# Altium schematic sheet FPGA.SchDoc(FPGA)
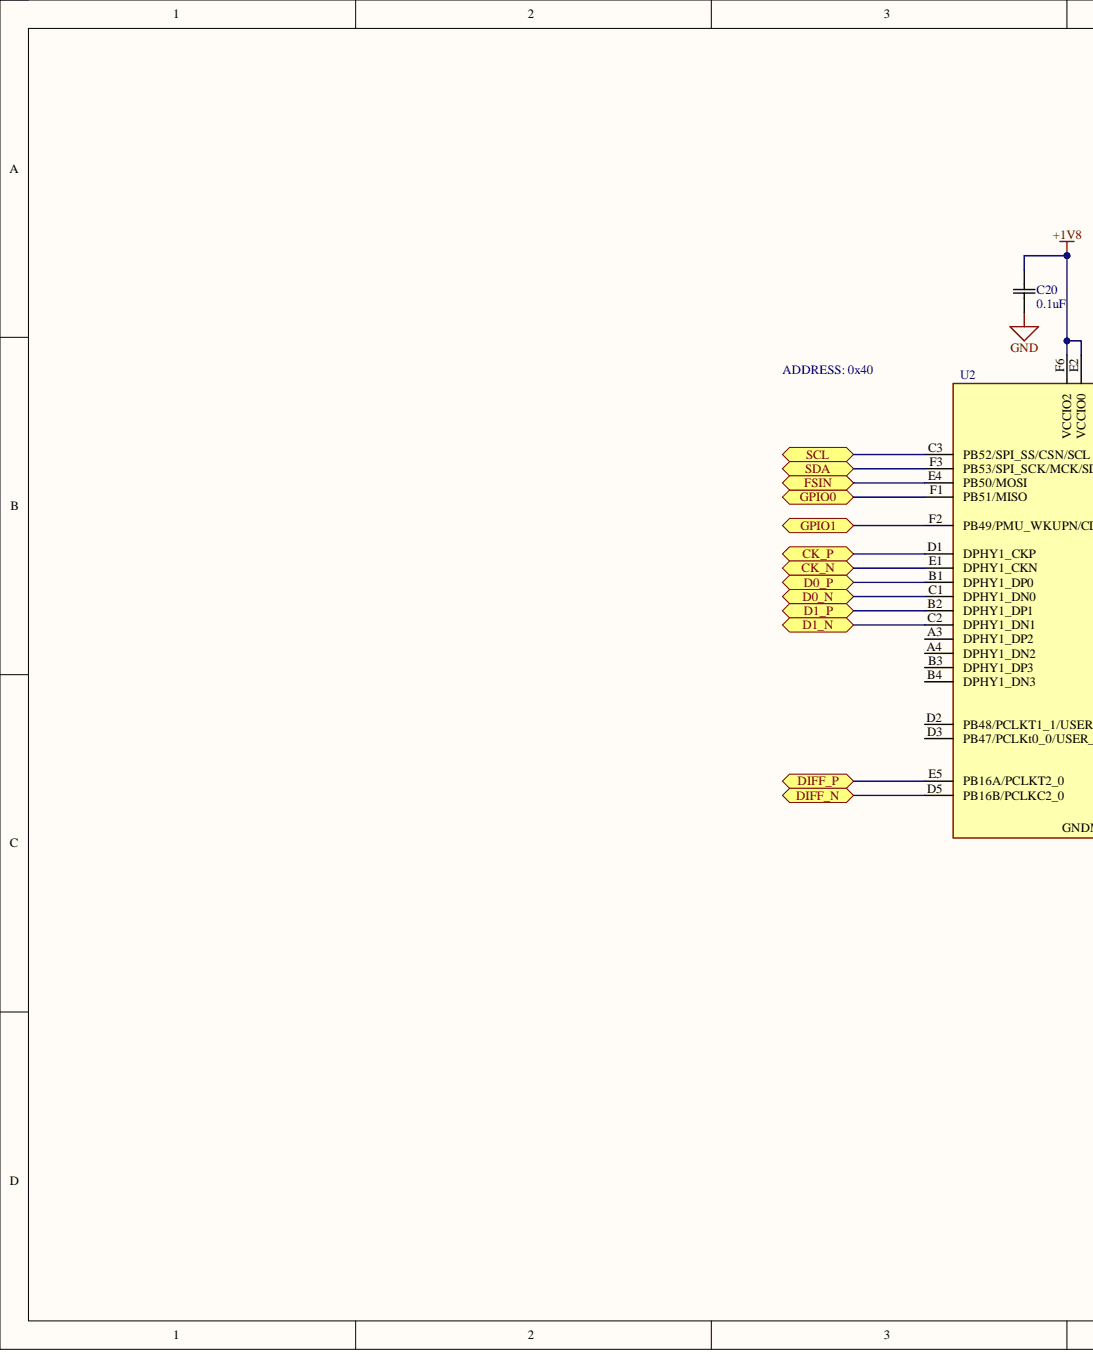
[diagram p0: FPGA.SchDoc, left]
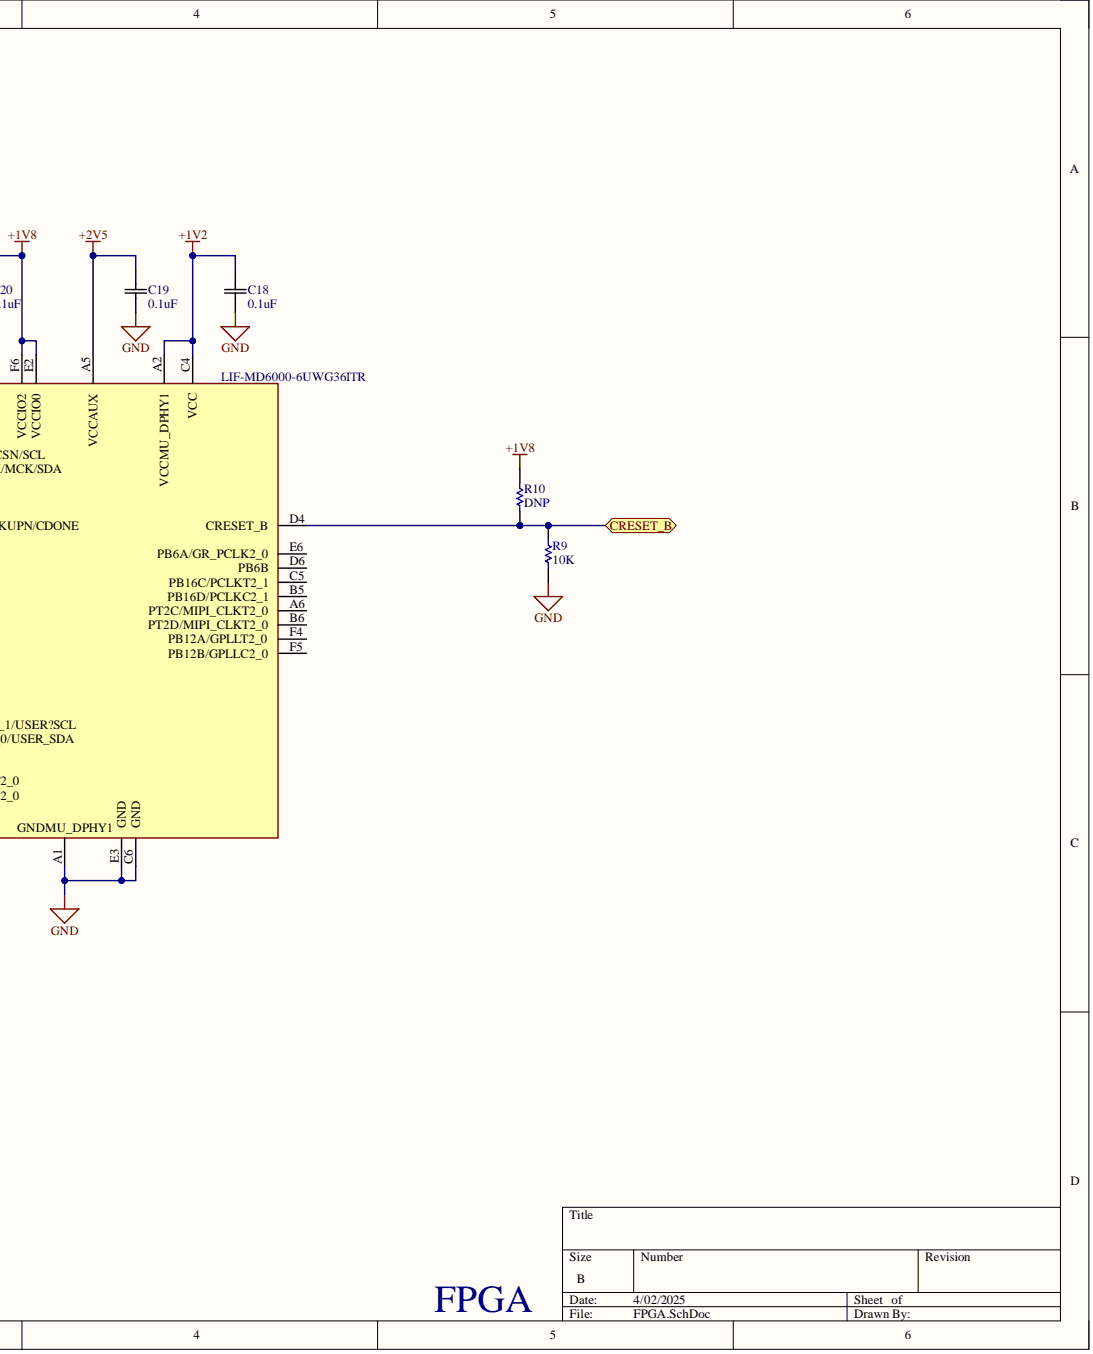
[diagram p1: FPGA.SchDoc, right]

## Parts
C18  0.1uF
C19  0.1uF
C20  0.1uF
R9  10K
R10  DNP
U2  LIF-MD6000-6UWG36ITR

## Ports
CK_N, CK_P, CRESET_B, D0_N, D0_P, D1_N, D1_P, DIFF_N, DIFF_P, FSIN, GPIO0, GPIO1, SCL, SDA

## Nets
+1V2: C18.2 U2.A2(VCCMU_DPHY1) U2.C4(VCC)
+1V8: C20.2 R10.2 U2.E2(VCCIO0) U2.F6(VCCIO2)
+2V5: C19.2 U2.A5(VCCAUX)
GND: C18.1 C19.1 C20.1 R9.1 U2.A1 U2.C6(GND) U2.E3(GND)
CK_N: U2.E1(DPHY1_CKN) ; port CK_N
CK_P: U2.D1(DPHY1_CKP) ; port CK_P
CRESET_B: R9.2 R10.1 U2.D4(CRESET_B) ; port CRESET_B
D0_N: U2.C1(DPHY1_DN0) ; port D0_N
D0_P: U2.B1(DPHY1_DP0) ; port D0_P
D1_N: U2.C2(DPHY1_DN1) ; port D1_N
D1_P: U2.B2(DPHY1_DP1) ; port D1_P
DIFF_N: U2.D5(PB16B/PCLKC2_0) ; port DIFF_N
DIFF_P: U2.E5(PB16A/PCLKT2_0) ; port DIFF_P
FSIN: U2.E4(PB50/MOSI) ; port FSIN
GPIO0: U2.F1(PB51/MISO) ; port GPIO0
GPIO1: U2.F2(PB49/PMU_WKUPN/CDONE) ; port GPIO1
SCL: U2.C3(PB52/SPI_SS/CSN/SCL) ; port SCL
SDA: U2.F3(PB53/SPI_SCK/MCK/SDA) ; port SDA

Not connected: U2 A3(DPHY1_DP2) A4(DPHY1_DN2) A6(PT2C/MIPI_CLKT2_0) B3(DPHY1_DP3) B4(DPHY1_DN3) B5(PB16D/PCLKC2_1) B6(PT2D/MIPI_CLKT2_0) C5(PB16C/PCLKT2_1) D2(PB48/PCLKT1_1/USER?SCL) D3(PB47/PCLKt0_0/USER_SDA) D6(PB6B) E6(PB6A/GR_PCLK2_0) F4(PB12A/GPLLT2_0) F5(PB12B/GPLLC2_0)

## Library data of the parts
C18-C20 = GRM033R60J104KE19D: CAP,0.1µF ±10% 6.3V Ceramic Capacitor X5R 0201 (0603 Metric); Mfr_Name: Yageo; Component Kind: Standard; Library Name: 7000-0xxx Camera PCA Rev 0.SCHLIB
R9 R10 = CRCW020110K0FNED: RES, 10 kOhms Â±1% 0.05W, 1/20W Chip Resistor 0201 _0603 Metric_ Thick Film; MF: Vishay Dale; MP: CRCW0201100KFNED; Availability: Unavailable; Component Kind: Standard; Library Name: 7000-0303 Camera PCA Rev 0.SCHLIB; Package: 0603 Vishay; Price: None; SnapEDA_Link: https://www.snapeda.com/parts/CRCW0201100KFNED/Vishay%20Dale/view-part/3656241/?ref=snap
U2 = LIF-MD6000-6UWG36ITR: IC, FPGA - Field Programmable Gate Array Crosslink Interface MIPI D-Phy Bridge; Manufacturer_Name: Microchip; Manufacturer_Part_Number: A3P030-VQG100I; Geometry.Height: 1.2mm; Component Kind: Standard; Datasheet Link: https://www.microsemi.com/document-portal/doc_download/130704-ds0097-proasic3-family-flash-fpgas-datasheet; Library Name: 7000-0xxx Camera PCA Rev 0.SCHLIB; Mouser Part Number: 494-A3P030-VQG100I; Mouser Price/Stock: https://www.mouser.co.uk/ProductDetail/Microchip-Technology/A3P030-VQG100I?qs=sScscP4KMkhNAy2pUobyCQ%3D%3D
